# Altium schematic sheet ICWSHLP2_Adaptor_2x2_Voltage_supervisor.SchDoc(ICWSHLP2_Adaptor_2x2_Voltage_supervisor)
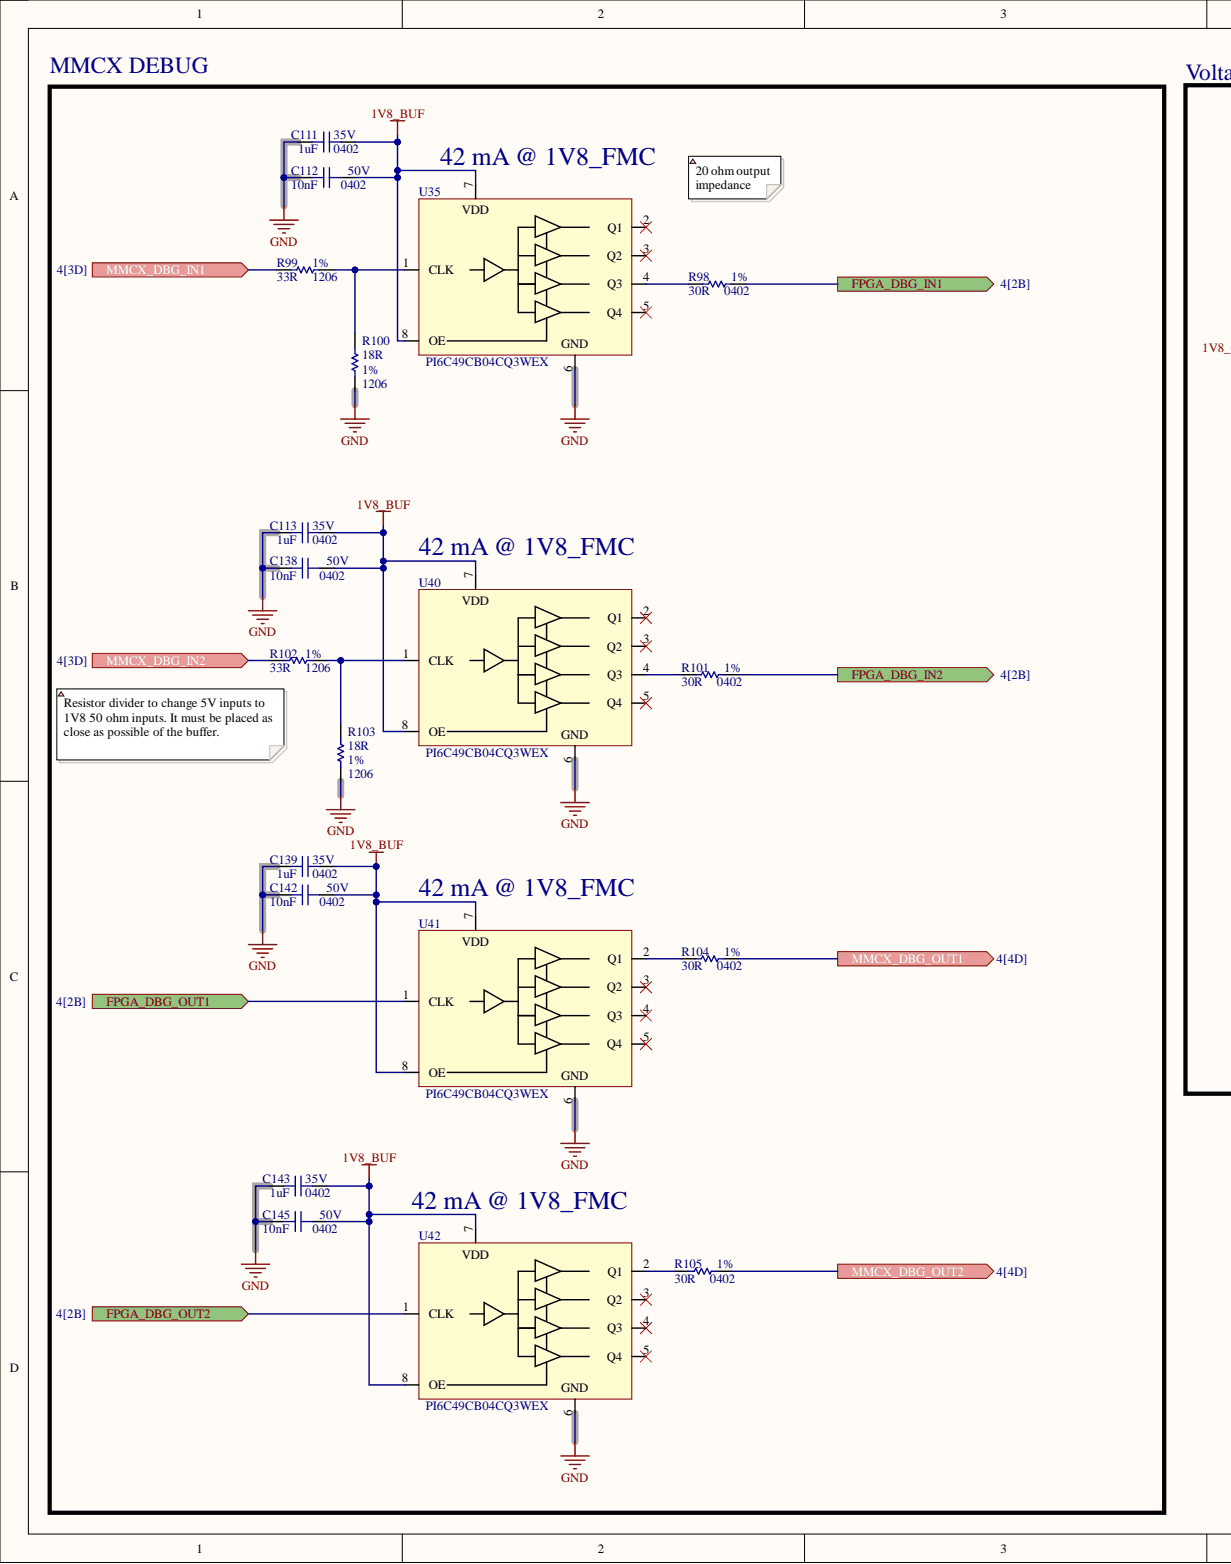
[diagram p0: ICWSHLP2_Adaptor_2x2_Voltage_supervisor.SchDoc, left]
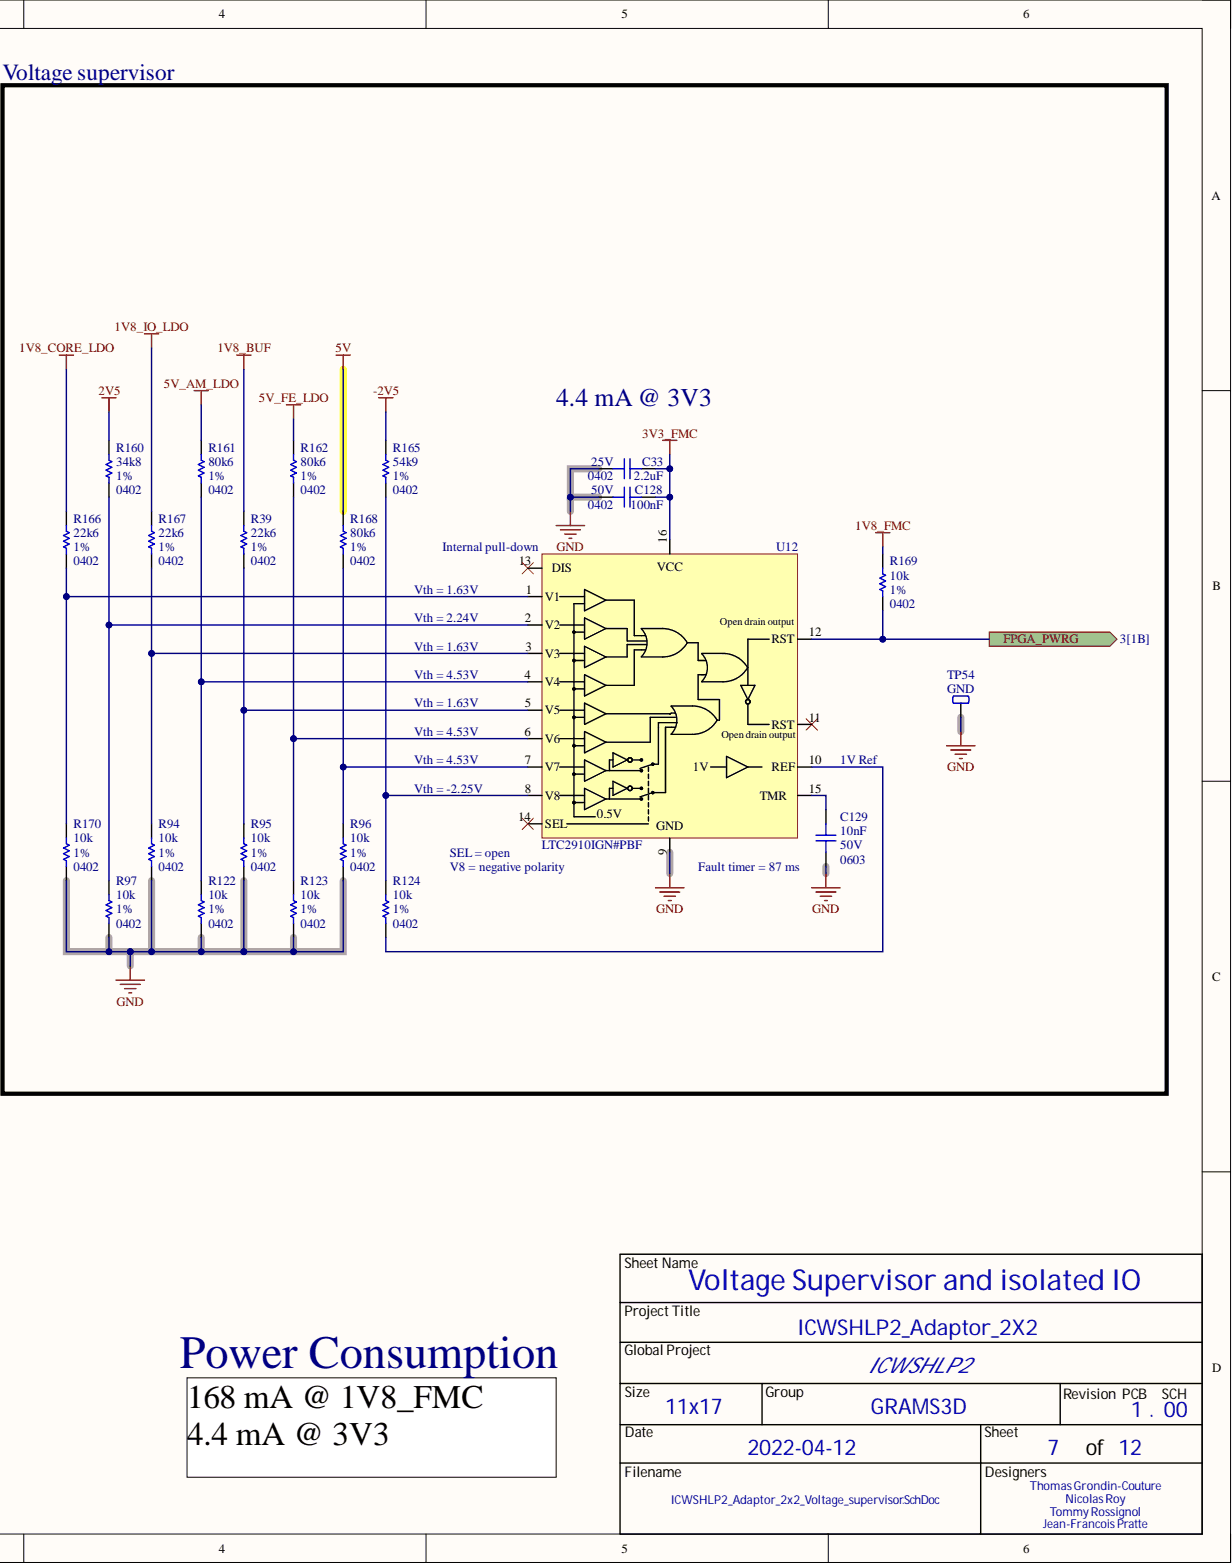
[diagram p1: ICWSHLP2_Adaptor_2x2_Voltage_supervisor.SchDoc, right]

## Parts
C33  value 2.2uF  Package: 0402  Voltage Rating: 25V  [CAP_CER_0402]  (hidden comment: GRT155R61E225KE13D)
C111  value 1uF  Package: 0402  Voltage Rating: 35V  [CAP_CER_0402]  (hidden comment: CL05A105KL5NRNC)
C112  value 10nF  Package: 0402  Voltage Rating: 50V  [CAP_CER_0402]  (hidden comment: GRM155R71H103KA88D)
C113  value 1uF  Package: 0402  Voltage Rating: 35V  [CAP_CER_0402]  (hidden comment: CL05A105KL5NRNC)
C128  value 100nF  Package: 0402  Voltage Rating: 50V  [CAP_CER_0402]  (hidden comment: UMK105B7104KV-FR)
C129  value 10nF  Package: 0603  Voltage Rating: 50V  [CAP_CER_0603]  (hidden comment: GRM1885C1H103JA01D)
C138  value 10nF  Package: 0402  Voltage Rating: 50V  [CAP_CER_0402]  (hidden comment: GRM155R71H103KA88D)
C139  value 1uF  Package: 0402  Voltage Rating: 35V  [CAP_CER_0402]  (hidden comment: CL05A105KL5NRNC)
C142  value 10nF  Package: 0402  Voltage Rating: 50V  [CAP_CER_0402]  (hidden comment: GRM155R71H103KA88D)
C143  value 1uF  Package: 0402  Voltage Rating: 35V  [CAP_CER_0402]  (hidden comment: CL05A105KL5NRNC)
C145  value 10nF  Package: 0402  Voltage Rating: 50V  [CAP_CER_0402]  (hidden comment: GRM155R71H103KA88D)
R39  value 22k6  Package: 0402  Tolerance: 1%  [RES_0402]  (hidden comment: RC0402FR-0722K6L)
R94  value 10k  Package: 0402  Tolerance: 1%  [RES_0402]  (hidden comment: RC0402FR-0710KL)
R95  value 10k  Package: 0402  Tolerance: 1%  [RES_0402]  (hidden comment: RC0402FR-0710KL)
R96  value 10k  Package: 0402  Tolerance: 1%  [RES_0402]  (hidden comment: RC0402FR-0710KL)
R97  value 10k  Package: 0402  Tolerance: 1%  [RES_0402]  (hidden comment: RC0402FR-0710KL)
R98  value 30R  Package: 0402  Tolerance: 1%  [RES_0402]  (hidden comment: RC0402FR-0730RL)
R99  value 33R  Package: 1206  Tolerance: 1%  [RES_1206]  (hidden comment: CRGP1206F33R)
R100  value 18R  Package: 1206  Tolerance: 1%  [RES_1206]  (hidden comment: CRGP1206F18R)
R101  value 30R  Package: 0402  Tolerance: 1%  [RES_0402]  (hidden comment: RC0402FR-0730RL)
R102  value 33R  Package: 1206  Tolerance: 1%  [RES_1206]  (hidden comment: CRGP1206F33R)
R103  value 18R  Package: 1206  Tolerance: 1%  [RES_1206]  (hidden comment: CRGP1206F18R)
R104  value 30R  Package: 0402  Tolerance: 1%  [RES_0402]  (hidden comment: RC0402FR-0730RL)
R105  value 30R  Package: 0402  Tolerance: 1%  [RES_0402]  (hidden comment: RC0402FR-0730RL)
R122  value 10k  Package: 0402  Tolerance: 1%  [RES_0402]  (hidden comment: RC0402FR-0710KL)
R123  value 10k  Package: 0402  Tolerance: 1%  [RES_0402]  (hidden comment: RC0402FR-0710KL)
R124  value 10k  Package: 0402  Tolerance: 1%  [RES_0402]  (hidden comment: RC0402FR-0710KL)
R160  value 34k8  Package: 0402  Tolerance: 1%  [RES_0402]  (hidden comment: ERJ-2RKF3482X)
R161  value 80k6  Package: 0402  Tolerance: 1%  [RES_0402]  (hidden comment: RC0402FR-0780K6L)
R162  value 80k6  Package: 0402  Tolerance: 1%  [RES_0402]  (hidden comment: RC0402FR-0780K6L)
R165  value 54k9  Package: 0402  Tolerance: 1%  [RES_0402]  (hidden comment: RC0402FR-0754K9L)
R166  value 22k6  Package: 0402  Tolerance: 1%  [RES_0402]  (hidden comment: RC0402FR-0722K6L)
R167  value 22k6  Package: 0402  Tolerance: 1%  [RES_0402]  (hidden comment: RC0402FR-0722K6L)
R168  value 80k6  Package: 0402  Tolerance: 1%  [RES_0402]  (hidden comment: RC0402FR-0780K6L)
R169  value 10k  Package: 0402  Tolerance: 1%  [RES_0402]  (hidden comment: RC0402FR-0710KP)
R170  value 10k  Package: 0402  Tolerance: 1%  [RES_0402]  (hidden comment: RC0402FR-0710KL)
TP54  GND  [TPO_SMD_RCU-0C]
U12  LTC2910IGN#PBF  [POW_LTC2910IGN#PBF]
U35  PI6C49CB04CQ3WEX  [TIM_FAN_PI6C49CB04CQ3WEX]  (value not drawn)
U40  PI6C49CB04CQ3WEX  [TIM_FAN_PI6C49CB04CQ3WEX]  (value not drawn)
U41  PI6C49CB04CQ3WEX  [TIM_FAN_PI6C49CB04CQ3WEX]  (value not drawn)
U42  PI6C49CB04CQ3WEX  [TIM_FAN_PI6C49CB04CQ3WEX]  (value not drawn)

## Ports
FPGA_DBG_IN1, FPGA_DBG_IN2, FPGA_DBG_OUT1, FPGA_DBG_OUT2, FPGA_PWRG, MMCX_DBG_IN1, MMCX_DBG_IN2, MMCX_DBG_OUT1, MMCX_DBG_OUT2

## Nets
1V8_BUF: C111.1 C112.1 C113.1 C138.1 C139.1 C142.1 C143.1 C145.1 R39.2 U35.7 U35.8(OE) U40.7 U40.8(OE) U41.7 U41.8(OE) U42.7 U42.8(OE)
1V8_CORE_LDO: R166.2
1V8_FMC: R169.2
1V8_IO_LDO: R167.2
2V5: R160.2
3V3_FMC: C33.1 C128.1 U12.16
5V: R168.2
5V_AM_LDO: R161.2
5V_FE_LDO: R162.2
-2V5: R165.2
GND: C33.2 C111.2 C112.2 C113.2 C128.2 C129.1 C138.2 C139.2 C142.2 C143.2 C145.2 R94.1 R95.1 R96.1 R97.1 R100.1 R103.1 R122.1 R123.1 R170.1 TP54.1 U12.9 U35.6 U40.6 U41.6 U42.6
FPGA_DBG_IN1: R98.1 ; port FPGA_DBG_IN1
FPGA_DBG_IN2: R101.1 ; port FPGA_DBG_IN2
FPGA_DBG_OUT1: U41.1(CLK) ; port FPGA_DBG_OUT1
FPGA_DBG_OUT2: U42.1(CLK) ; port FPGA_DBG_OUT2
FPGA_PWRG: R169.1 U12.12(RST) ; port FPGA_PWRG
MMCX_DBG_IN1: R99.2 ; port MMCX_DBG_IN1
MMCX_DBG_IN2: R102.2 ; port MMCX_DBG_IN2
MMCX_DBG_OUT1: R104.1 ; port MMCX_DBG_OUT1
MMCX_DBG_OUT2: R105.1 ; port MMCX_DBG_OUT2
NetC129_2: C129.2 U12.15(TMR)
NetR39_1: R39.1 R95.2 U12.5(V5)
NetR94_2: R94.2 R167.1 U12.3(V3)
NetR96_2: R96.2 R168.1 U12.7(V7)
NetR97_2: R97.2 R160.1 U12.2(V2)
NetR98_2: R98.2 U35.4(Q3)
NetR99_1: R99.1 R100.2 U35.1(CLK)
NetR101_2: R101.2 U40.4(Q3)
NetR102_1: R102.1 R103.2 U40.1(CLK)
NetR104_2: R104.2 U41.2(Q1)
NetR105_2: R105.2 U42.2(Q1)
NetR122_2: R122.2 R161.1 U12.4(V4)
NetR123_2: R123.2 R162.1 U12.6(V6)
NetR124_1: R124.1 U12.10(REF)
NetR124_2: R124.2 R165.1 U12.8(V8)
NetR166_1: R166.1 R170.2 U12.1(V1)

Not connected: U12 11(RST) 13(DIS) 14(SEL); U35 2(Q1) 3(Q2) 5(Q4); U40 2(Q1) 3(Q2) 5(Q4); U41 3(Q2) 4(Q3) 5(Q4); U42 3(Q2) 4(Q3) 5(Q4)

## Library data of the parts
C33 = CAP_CER_GRT155R61E225KE13D: CAP - CER 2.2uF ±10% 25V X5R - 0402; Manufacturer #1: Murata Electronics North America; Cryo: UNKNOWN; GRAMS Lib Spec: 2.8; Note: 2uF@1.5V 1uF@5V 0.55uF@10V; Polarized: No; Assembly Type: SMD; Component Kind: Standard; HelpURL: http://search.murata.co.jp/Ceramy/image/img/A01X/G101/ENG/GRT155R61E225KE13-01.pdf; Library Name: GRAMS_MASTER_LIB.IntLib; Magnetic Material: Unknown; Part Number #1: GRT155R61E225KE13D; Supplier 1: Digi-Key; Supplier Part Number 1: 490-12267-1-ND; Temperature: -55°C ~ 85°C; Tolerance: 10%; CreatedBy/Date: Keven Deslandes - 2018/12/18; VerifiedBy: Caroline Paulin - 23 janv 2019
C111 C113 C139 C143 = CAP_CER_CL05A105KL5NRNC: CAP - CER 1uF 10% 35V X5R - 0402; Manufacturer #1: Samsung Electro-Mechanics; Cryo: UNKNOWN; GRAMS Lib Spec: 2.8; Polarized: NO; Assembly Type: SMD; Component Kind: Standard; HelpURL: http://www.samsungsem.com/kr/support/product-search/mlcc/__icsFiles/afieldfile/2018/06/19/CL05A105KL5NRNC.pdf; Library Name: GRAMS_MASTER_LIB.IntLib; Magnetic Material: Unknown; Part Number #1: CL05A105KL5NRNC; Supplier 1: Digi-Key; Supplier Part Number 1: 1276-6796-1-ND; Temperature: -55°C ~ 85°C; Tolerance: 10%; CreatedBy/Date: Nicolas Roy 2018-10-03; VerifiedBy: Caroline Paulin - 18 janv 2019
C112 C138 C142 C145 = CAP_CER_GRM155R71H103KA88D: CAP - CER 10nF 10% 50V X7R - 0402; Manufacturer #1: Murata Electronics North America; Cryo: UNKNOWN; GRAMS Lib Spec: 2.8; Polarized: No; Assembly Type: SMD; Component Kind: Standard; HelpURL: https://search.murata.co.jp/Ceramy/image/img/A01X/G101/ENG/GRM155R71H103KA88-01.pdf; Library Name: GRAMS_MASTER_LIB.IntLib; Magnetic Material: Unknown; Part Number #1: GRM155R71H103KA88D; Supplier 1: Digi-Key; Supplier Part Number 1: 490-4516-1-ND; Temperature: -55°C ~ 125°C; Tolerance: 10%; CreatedBy/Date: C.Paulin - 19/06/2019; VerifiedBy: Nicolas V. (2019-07-04)
C128 = CAP_CER_UMK105B7104KV-FR: CAP - CER 100nF ±10% 50V X7R - 0402; Manufacturer #1: Taiyo Yuden; Cryo: UNKNOWN; GRAMS Lib Spec: 2.8; Note: DC bias losses = -25%@5V, -55%@10V; Polarized: No; Assembly Type: SMD; Component Kind: Standard; HelpURL: https://ds.yuden.co.jp/TYCOMPAS/ut/detail?pn=UMK105B7104KV-FR%20%20&u=M; Library Name: GRAMS_MASTER_LIB.IntLib; Magnetic Material: Unknown; Part Number #1: UMK105B7104KV-FR; Supplier 1: Digi-Key; Supplier Part Number 1: 587-3498-1-ND; Temperature: -55°C ~ 125°C; Tolerance: 10%; CreatedBy/Date: Keven Deslandes - 2018/12/18; VerifiedBy: Caroline Paulin - 23 janv 2019
C129 = CAP_CER_GRM1885C1H103JA01D: CAP - CER 10nF 5% 50V C0G/NP0 - 0603; Manufacturer #1: Murata Electronics North America; Cryo: UNKNOWN; GRAMS Lib Spec: 2.8; Polarized: NO; Assembly Type: SMD; Component Kind: Standard; HelpURL: https://www.murata.com/~/media/webrenewal/support/library/catalog/products/capacitor/mlcc/c02e.ashx?la=en-us; Library Name: GRAMS_MASTER_LIB.IntLib; Magnetic Material: Unknown; Part Number #1: GRM1885C1H103JA01D; Supplier 1: Digi-Key; Supplier Part Number 1: 490-9666-1-ND; Temperature: -55°C ~ 125°C; Tolerance: 5%; CreatedBy/Date: Nicolas Roy 2018/12/19; VerifiedBy: Nicolas V. (2019-01-22)
R39 R166 R167 = RES_RC0402FR-0722K6L: RES - 22.6k 1% 1/16W - 0402; Manufacturer #1: Yageo; Cryo: UNKNOWN; GRAMS Lib Spec: 2.8; Polarized: NO; Voltage Rating: 50V; Assembly Type: SMD; Component Kind: Standard; HelpURL: http://www.yageo.com/documents/recent/PYu-RC_Group_51_RoHS_L_10.pdf; Library Name: GRAMS_MASTER_LIB.IntLib; Magnetic Material: Unknown; Part Number #1: RC0402FR-0722K6L; Power Max: 1/16W; Supplier 1: Digi-Key; Supplier Part Number 1: YAG3061CT-ND; Temperature: -55°C ~ 155°C; CreatedBy/Date: Nicolas Roy/23 oct. 2018; VerifiedBy: Caroline Paulin - 7 janv 2019
R94-R97 R122-R124 R170 = RES_RC0402FR-0710KL: RES - 10K OHMS 1% 1/16W - 0402; Manufacturer #1: Yageo; Cryo: UNKNOWN; GRAMS Lib Spec: 2.8; Polarized: NO; Voltage Rating: 50V; Assembly Type: SMD; Component Kind: Standard; HelpURL: http://www.yageo.com/documents/recent/PYu-RC_Group_51_RoHS_L_9.pdf; Library Name: GRAMS_MASTER_LIB.IntLib; Magnetic Material: UNKNOWN; Part Number #1: RC0402FR-0710KL; Power Max: 1/16W; Supplier 1: Digi-Key; Supplier Part Number 1: 311-10.0KLRCT-ND; Temperature: -55°C ~ 155°C; CreatedBy/Date: Nicolas V. (2018-11-20); VerifiedBy: Nicolas V. (2018-11-20)
R98 R101 R104 R105 = RES_RC0402FR-0730RL: RES - 30R 1% 1/16W - 0402; Manufacturer #1: Yageo; Cryo: UNKNOWN; GRAMS Lib Spec: 2.8; Polarized: No; Voltage Rating: 50V; Assembly Type: SMD; Component Kind: Standard; HelpURL: http://www.yageo.com/documents/recent/PYu-RC_Group_51_RoHS_L_10.pdf; Library Name: GRAMS_MASTER_LIB.IntLib; Magnetic Material: Unknown; Part Number #1: RC0402FR-0730RL; Power Max: 1/16W; Supplier 1: Digi-Key; Supplier Part Number 1: 311-30LRCT-ND; Temperature: -55°C ~ 155°C; CreatedBy/Date: Keven Deslandes 2018-09-27; VerifiedBy: Caroline Paulin - 8 janv 2019
R99 R102 = RES_CRGP1206F33R: CRGP 1206 33R 1%; Manufacturer #1: TE Connectivity Passive Product; Cryo: UNKNOWN; GRAMS Lib Spec: 2.9; Polarized: No; Power Max: 1/2W; Assembly Type: SMD; Component Kind: Standard; HelpURL: https://www.te.com/commerce/DocumentDelivery/DDEController?Action=srchrtrv&DocNm=9-1773463-9&DocType=DS&DocLang=English; Magnetic Material: UNKNOWN; Part Number #1: CRGP1206F33R; Solder Paste Type: UNKNOWN; Stencil Thickness (Recommended): UNKNOWN; Supplier 1: Digi-Key; Supplier Part Number 1: A130520CT-ND; Temperature: -55°C ~ 155°C; Voltage Rating: UNKNOWN; CreatedBy/Date: Thomas Grondin-Couture 2021-06-10; Library Name: Lib_temp_Thomas_GC.SchLib
R100 R103 = RES_CRGP1206F18R: CRGP 1206 18R 1%; Manufacturer #1: TE Connectivity Passive Product; Cryo: UNKNOWN; GRAMS Lib Spec: 2.9; Polarized: No; Power Max: 1/2W; Assembly Type: SMD; Component Kind: Standard; HelpURL: https://www.te.com/commerce/DocumentDelivery/DDEController?Action=srchrtrv&DocNm=9-1773463-9&DocType=DS&DocLang=English; Magnetic Material: UNKNOWN; Part Number #1: CRGP1206F18R; Solder Paste Type: UNKNOWN; Stencil Thickness (Recommended): UNKNOWN; Supplier 1: Digi-Key; Supplier Part Number 1: A130517CT-ND; Temperature: -55°C ~ 155°C; Voltage Rating: UNKNOWN; CreatedBy/Date: Thomas Grondin-Couture 2021-06-10; Library Name: Lib_temp_Thomas_GC.SchLib
R160 = RES_ERJ-2RKF3482X: RES - 34.8k OHM 1% 1/10W - 0402; Manufacturer #1: Panasonic Electronic Components; Cryo: UNKNOWN; GRAMS Lib Spec: 2.8; Polarized: NO; Voltage Rating: 50V; Assembly Type: SMD; Component Kind: Standard; HelpURL: https://industrial.panasonic.com/cdbs/www-data/pdf/RDA0000/AOA0000C304.pdf; Library Name: GRAMS_MASTER_LIB.IntLib; Magnetic Material: Unknown; Part Number #1: ERJ-2RKF3482X; Power Max: 1/10W; Supplier 1: Digi-Key; Supplier Part Number 1: P34.8KLCT-ND; Temperature: -55°C ~ 155°C; CreatedBy/Date: Nicolas Roy/23 oct. 2018; VerifiedBy: Caroline Paulin - 18/12/2018
R161 R162 R168 = RES_RC0402FR-0780K6L: RES - 80.6k OHM 1% 1/16W - 0402; Manufacturer #1: Yageo; Cryo: UNKNOWN; GRAMS Lib Spec: 2.8; Polarized: NO; Voltage Rating: 50V; Assembly Type: SMD; Component Kind: Standard; HelpURL: http://www.yageo.com/documents/recent/PYu-RC_Group_51_RoHS_L_10.pdf; Library Name: GRAMS_MASTER_LIB.IntLib; Magnetic Material: Unknown; Part Number #1: RC0402FR-0780K6L; Power Max: 1/16W; Supplier 1: Digi-Key; Supplier Part Number 1: 311-80.6KLRCT-ND; Temperature: -55°C ~ 155°C; CreatedBy/Date: Nicolas Roy/18 oct. 2018; VerifiedBy: Caroline Paulin - 8 janv 2019
R165 = RES_RC0402FR-0754K9L: RES - 54.9k 1% 1/16W - 0402; Manufacturer #1: Yageo; Cryo: UNKNOWN; GRAMS Lib Spec: 2.8; Polarized: NO; Voltage Rating: 50V; Assembly Type: SMD; Component Kind: Standard; HelpURL: http://www.yageo.com/documents/recent/PYu-RC_Group_51_RoHS_L_10.pdf; Library Name: GRAMS_MASTER_LIB.IntLib; Magnetic Material: Unknown; Part Number #1: RC0402FR-0754K9L; Power Max: 1/16W; Supplier 1: Digi-Key; Supplier Part Number 1: YAG3185CT-ND; Temperature: -55°C ~ 155°C; CreatedBy/Date: Nicolas Roy/23 oct. 2018; VerifiedBy: Caroline Paulin - 8 janv 2019
R169 = RES_RC0402FR-0710KP: RES - 10K OHM 1% 1/16W - 0402; Manufacturer #1: Yageo; Cryo: UNKNOWN; GRAMS Lib Spec: 2.8; Magnetic Material: YES; Polarized: NO; Voltage Rating: 50V; Assembly Type: SMD; Component Kind: Standard; HelpURL: http://www.yageo.com/documents/recent/PYu-RC_Group_51_RoHS_L_9.pdf; Library Name: GRAMS_MASTER_LIB.IntLib; Part Number #1: RC0402FR-0710KP; Power Max: 1/16W; Supplier 1: Digi-Key; Supplier Part Number 1: YAG1249CT-ND; Temperature: -55°C ~ 155°C; CreatedBy/Date: Jonathan Bouchard 2018-07-05; VerifiedBy: Arnaud Samson 16-07-18
TP54 = TPO_SMD_RCU-0C: TPO - TEST POINT PROBE PAD - 0603; Manufacturer #1: TE Connectivity AMP Connectors; Part Number #1: RCU-0C; Cryo: UNKNOWN; GRAMS Lib Spec: 2.8; Polarized: No; Value: 1.6 mm x 0.8 mm; Assembly Type: SMD; Component Kind: Standard; HelpURL: http://www.te.com/commerce/DocumentDelivery/DDEController?Action=srchrtrv&DocNm=1773266&DocType=DS&DocLang=English; Library Name: GRAMS_MASTER_LIB.IntLib; Magnetic Material: UNKNOWN; Package: 0603; Supplier 1: Digi-Key; Supplier Part Number 1: A106145CT-ND; CreatedBy/Date: Olivier Bernard / 20/5/2018; VerifiedBy: Caroline Paulin / 6 juillet 2018
U12 = POW_LTC2910IGN#PBF: POW - VOLTAGE MONITOR, OCTAL - 16-SSOP; Manufacturer #1: Linear Technology/Analog Devices; Cryo: UNKNOWN; GRAMS Lib Spec: 2.8; Polarized: Yes; Voltage Rating: 6V; Assembly Type: SMD; Component Kind: Standard; HelpURL: http://www.linear.com/docs/16417; Library Name: GRAMS_MASTER_LIB.IntLib; Magnetic Material: Unknown; Package: 16-SSOP; Part Number #1: LTC2910IGN#PBF; Supplier 1: Digi-Key; Supplier Part Number 1: LTC2910IGN#PBF-ND; Temperature: -40°C ~ 85°C (TA); CreatedBy/Date: Keven Deslandes - 2018/10/19; VerifiedBy: Caroline Paulin - 14/12/2018
U35 U40-U42 = TIM_FAN_PI6C49CB04CQ3WEX: TIM - LVCMOS Clock Buffer, 4CH, 160MHz, -  8-SOIC; Manufacturer #1: Diodes Incorporated; Cryo: UNKOWN; GRAMS Lib Spec: 2.9; Polarized: Yes; Voltage Rating: 1.425V ~ 3.6V; Assembly Type: SMD; Component Kind: Standard; Current Rating: -; HelpURL: https://www.diodes.com/assets/Datasheets/PI6C49CB04CQ.pdf; Library Name: GRAMS_MASTER_LIB.IntLib; Magnetic Material: UNKOWN; Manufacturer #2: -; Package: 8-SOIC; Part Number #1: PI6C49CB04CQ3WEX; Part Number #2: -; Power Max: -; Supplier 1: Digi-key; Supplier Part Number 1: 31-PI6C49CB04CQ3WEXCT-ND; Temperature: -40°C ~ 85°C (TA); Tolerance: -; Value: -; CreatedBy/Date: Thomas Grondin-Couture / 2020-09-16; VerifiedBy: C.Paulin - 28 oct 2020
